# Altium schematic sheet MicroPET Base.SchDoc("MicroPET Base")
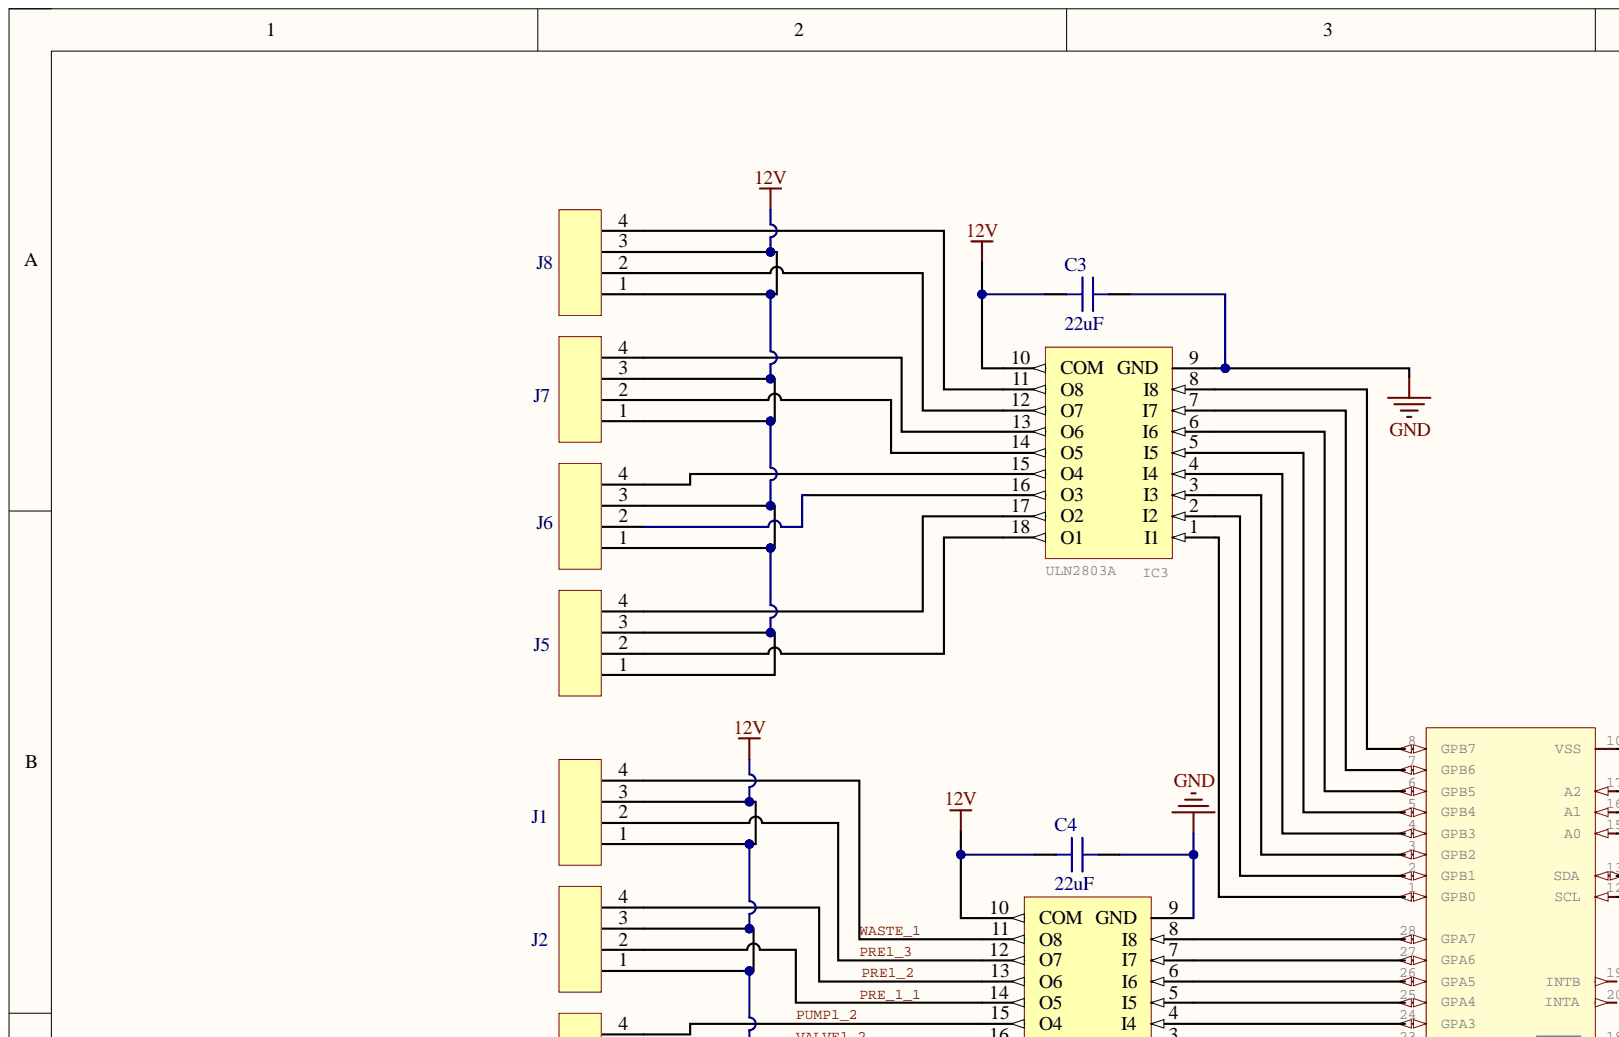
[diagram p0: MicroPET Base.SchDoc, top left]
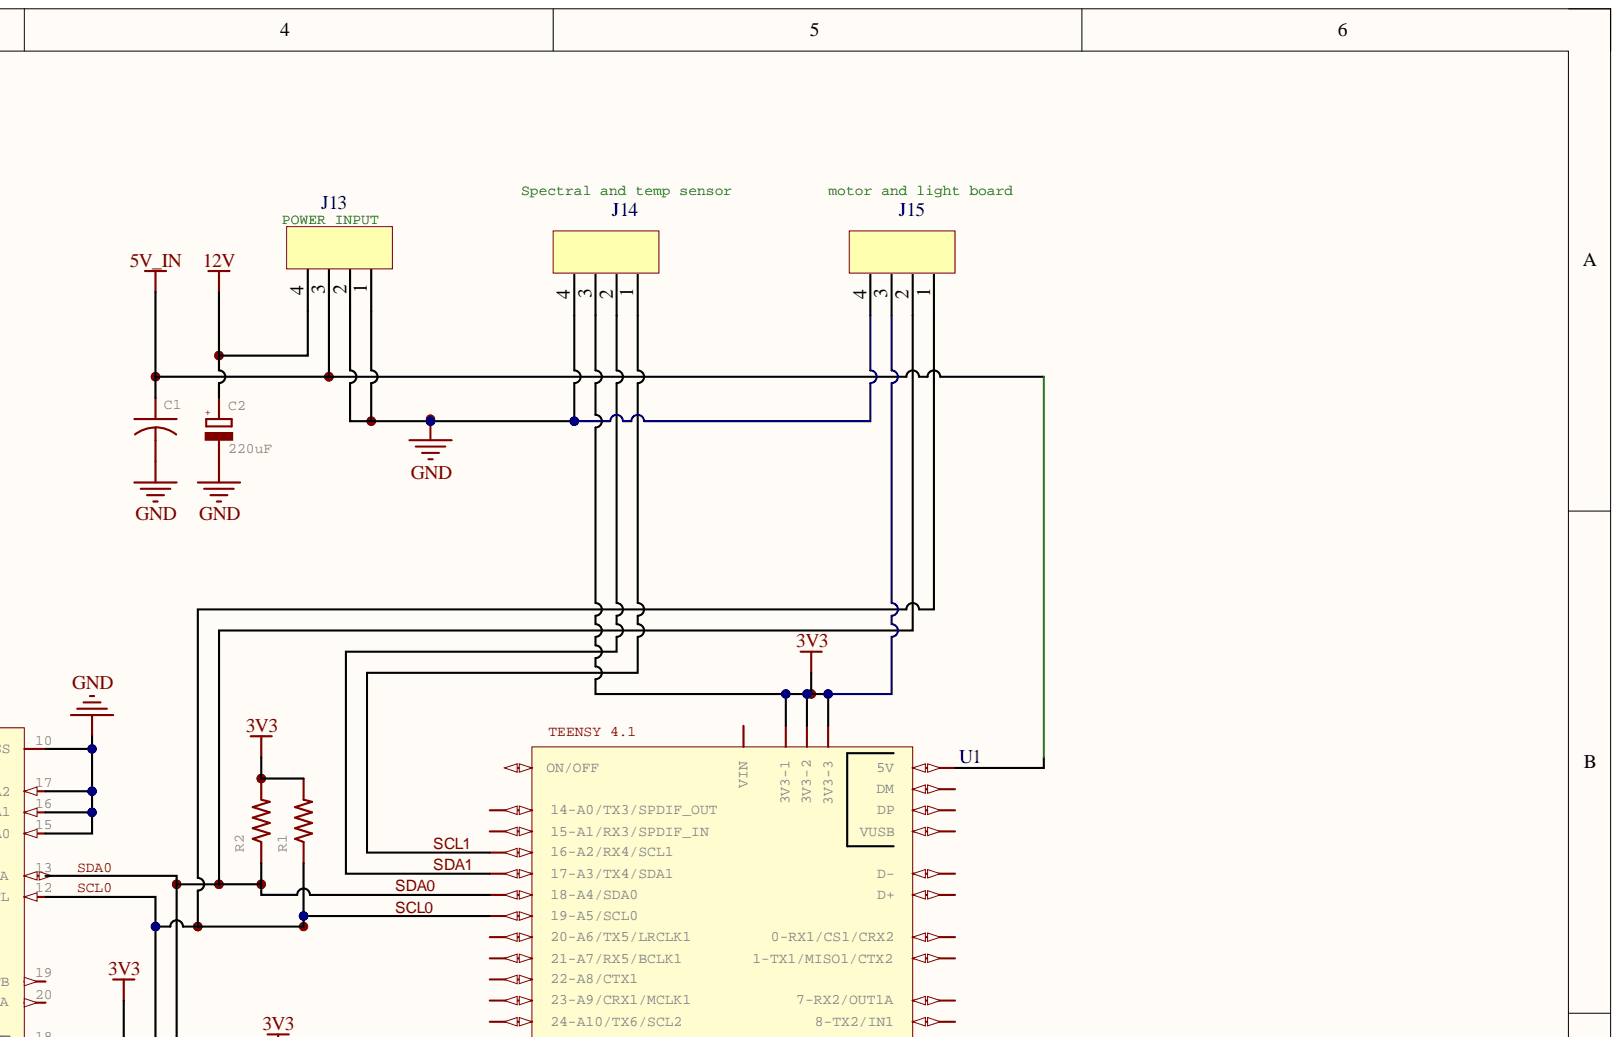
[diagram p1: MicroPET Base.SchDoc, top right]
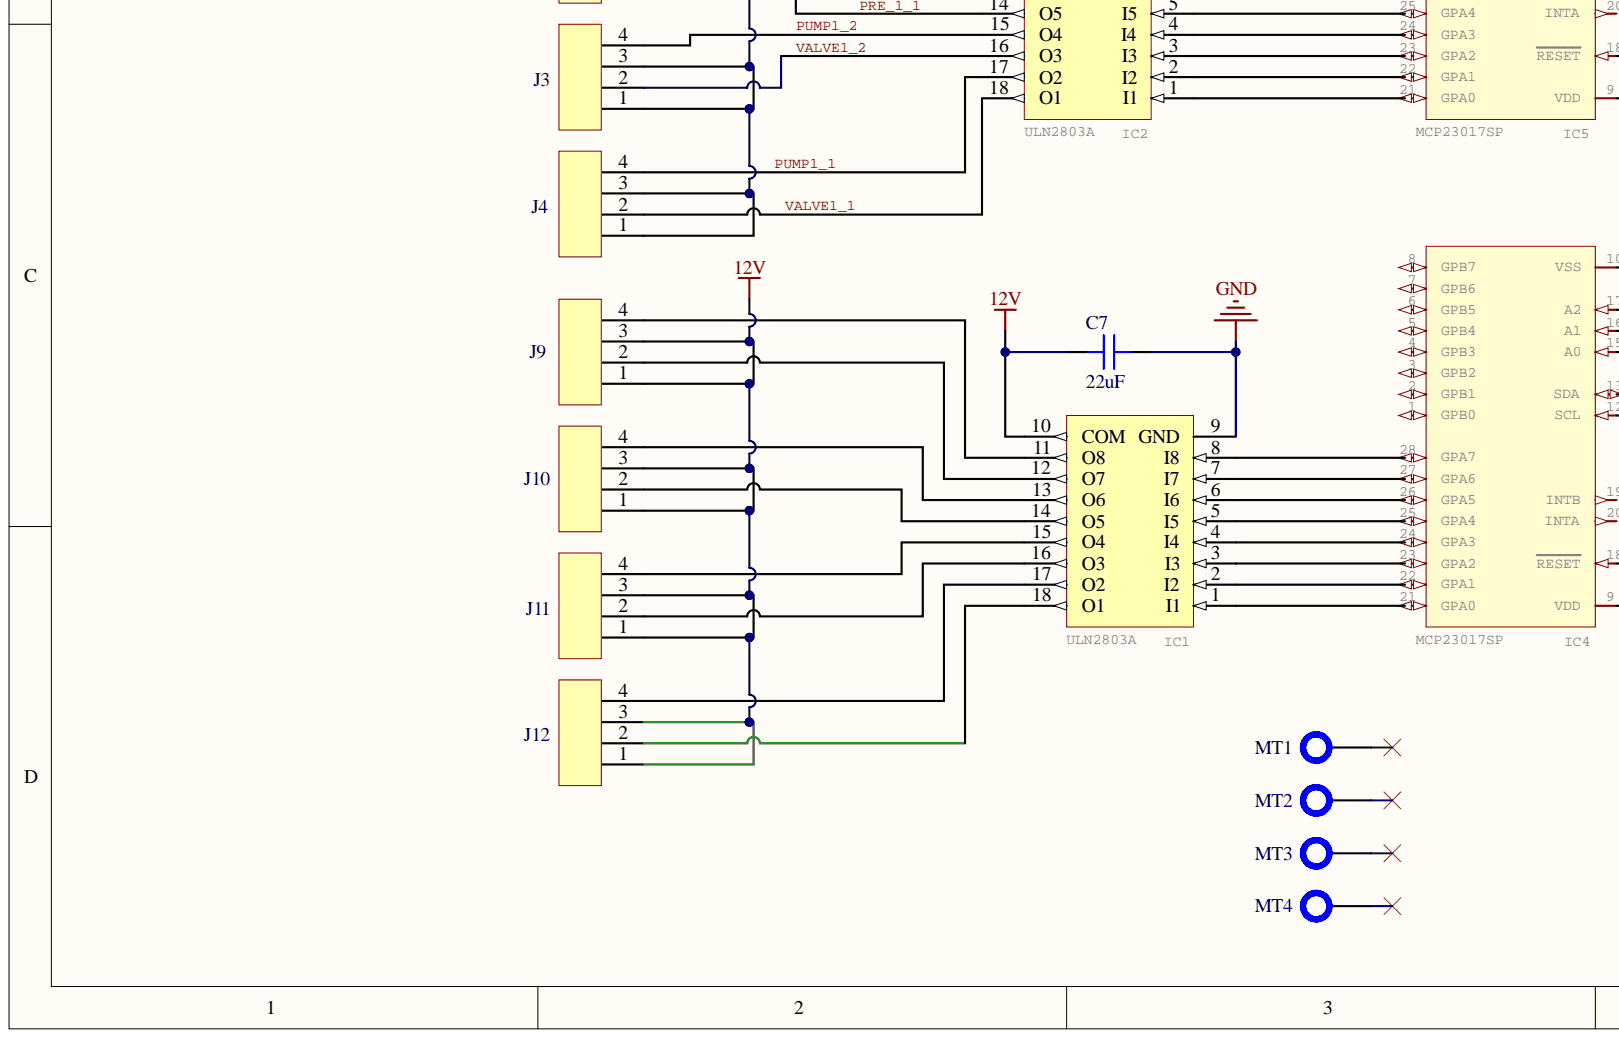
[diagram p2: MicroPET Base.SchDoc, bottom left]
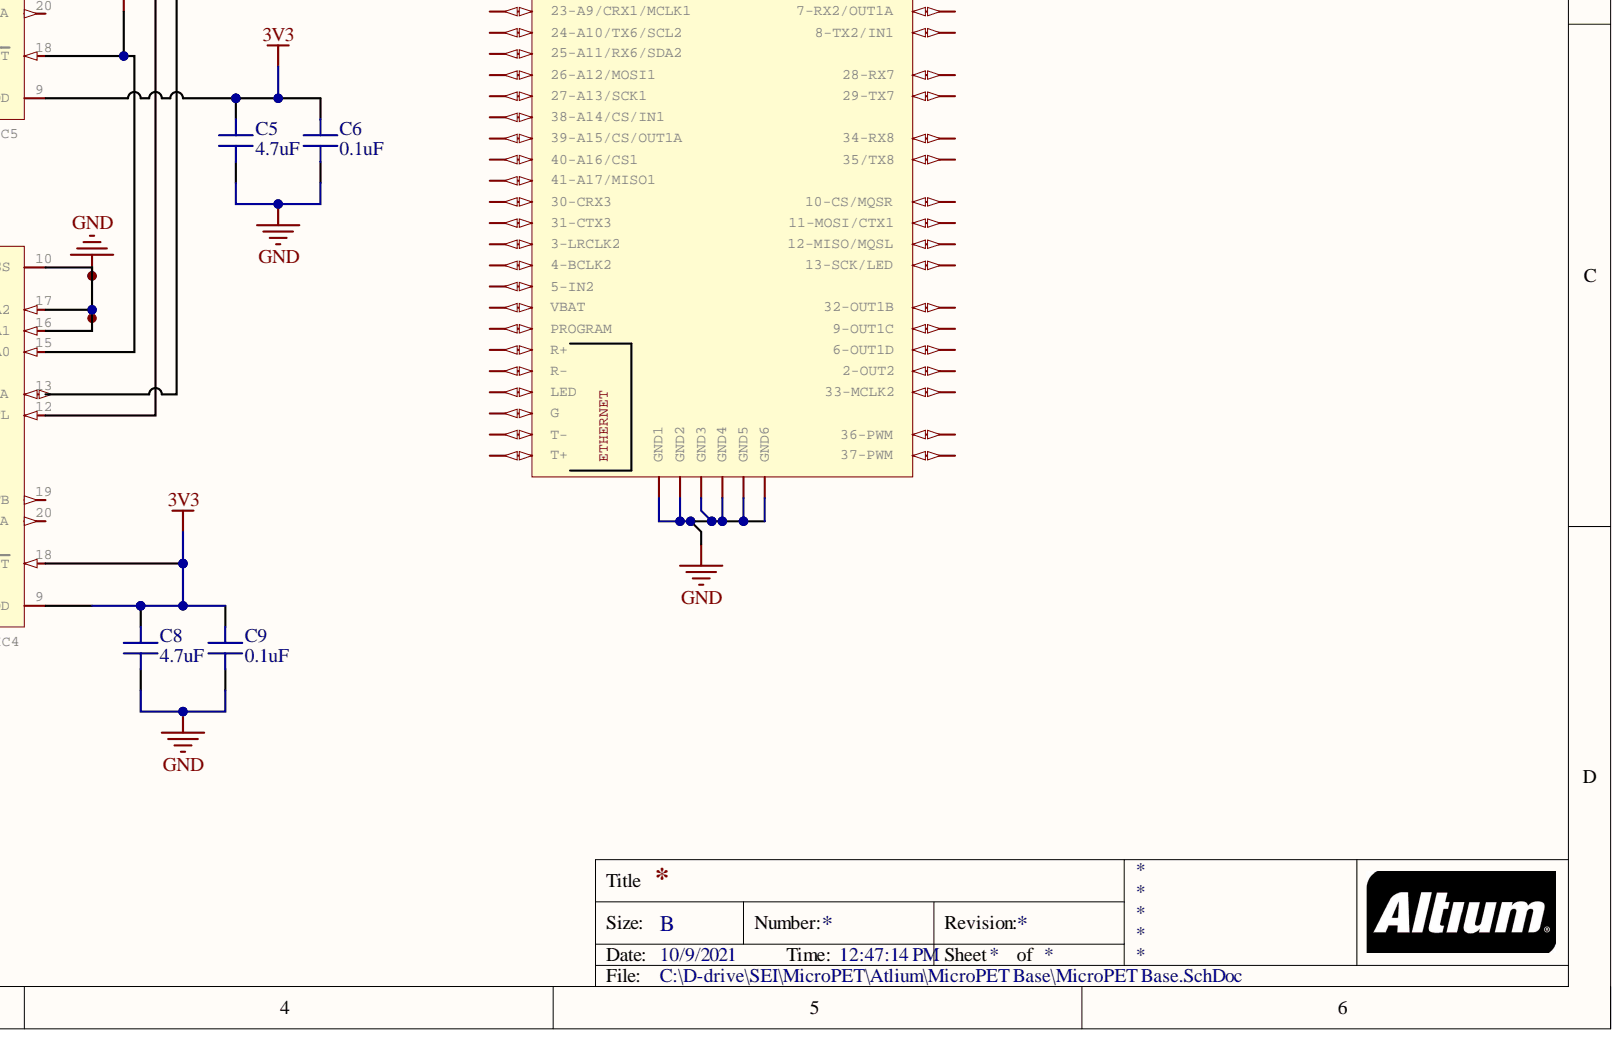
[diagram p3: MicroPET Base.SchDoc, bottom right]

## Parts
C1  C-USCHIP-1206(3216-METRIC)  [CAPC3216X135]  (value not drawn)
C2  220uF  [CAPPRD508W65D1000H1100B]
C3  22uF  [CAP_0805]
C4  22uF  [CAP_0805]
C5  4.7uF  [CAP_0603]
C6  0.1uF  [CAP_0402]
C7  22uF  [CAP_0805]
C8  4.7uF  [CAP_0603]
C9  0.1uF  [CAP_0402]
IC1  ULN2803A  [DIL18]
IC2  ULN2803A  [DIL18]
IC3  ULN2803A  [DIL18]
IC4  MCP23017SP  [DIL28-3]
IC5  MCP23017SP  [DIL28-3]
J1  B04B-ZESK-1D(T)(LF)(SN)(N)  [B04B-ZESK]  (value not drawn)
J2  B04B-ZESK-1D(T)(LF)(SN)(N)  [B04B-ZESK]  (value not drawn)
J3  B04B-ZESK-1D(T)(LF)(SN)(N)  [B04B-ZESK]  (value not drawn)
J4  B04B-ZESK-1D(T)(LF)(SN)(N)  [B04B-ZESK]  (value not drawn)
J5  B04B-ZESK-1D(T)(LF)(SN)(N)  [B04B-ZESK]  (value not drawn)
J6  B04B-ZESK-1D(T)(LF)(SN)(N)  [B04B-ZESK]  (value not drawn)
J7  B04B-ZESK-1D(T)(LF)(SN)(N)  [B04B-ZESK]  (value not drawn)
J8  B04B-ZESK-1D(T)(LF)(SN)(N)  [B04B-ZESK]  (value not drawn)
J9  B04B-ZESK-1D(T)(LF)(SN)(N)  [B04B-ZESK]  (value not drawn)
J10  B04B-ZESK-1D(T)(LF)(SN)(N)  [B04B-ZESK]  (value not drawn)
J11  B04B-ZESK-1D(T)(LF)(SN)(N)  [B04B-ZESK]  (value not drawn)
J12  B04B-ZESK-1D(T)(LF)(SN)(N)  [B04B-ZESK]  (value not drawn)
J13  B04B-ZESK-1D(T)(LF)(SN)(N)  [B04B-ZESK]  (value not drawn)
J14  B04B-ZESK-1D(T)(LF)(SN)(N)  [B04B-ZESK]  (value not drawn)
J15  B04B-ZESK-1D(T)(LF)(SN)(N)  [B04B-ZESK]  (value not drawn)
MT1  M3_MountHole  [M3_MountHole]  (value not drawn)  (no BOM)
MT2  M3_MountHole  [M3_MountHole]  (value not drawn)  (no BOM)
MT3  M3_MountHole  [M3_MountHole]  (value not drawn)  (no BOM)
MT4  M3_MountHole  [M3_MountHole]  (value not drawn)  (no BOM)
R1  R-US_R0603  [R0603]  (value not drawn)
R2  R-US_R0603  [R0603]  (value not drawn)
U1  TEENSY41  TEENSY4.1: TEENSY 4.1  [TEENSY41]

## Nets
3V3: C5.2 C6.2 C8.2 C9.2 IC4.9(VDD) IC4.15(A0) IC4.18(RESET) IC5.9(VDD) IC5.18(RESET) J14.3 J15.3 R1.2 R2.2 U1.3V3-1 U1.3V3-2 U1.3V3-3
5V_IN: C1.1 J13.3 U1.+5V(5V)
12V: C2.1 C3.1 C4.1 C7.1 IC1.10(COM) IC2.10(COM) IC3.10(COM) J1.1 J1.3 J2.1 J2.3 J3.1 J3.3 J4.1 J4.3 J5.1 J5.3 J6.1 J6.3 J7.1 J7.3 J8.1 J8.3 J9.1 J9.3 J10.1 J10.3 J11.1 J11.3 J12.1 J12.3 J13.4
GND: C1.2 C2.2 C3.2 C4.2 C5.1 C6.1 C7.2 C8.1 C9.1 IC1.9(GND) IC2.9(GND) IC3.9(GND) IC4.10(VSS) IC4.16(A1) IC4.17(A2) IC5.10(VSS) IC5.15(A0) IC5.16(A1) IC5.17(A2) J13.1 J13.2 J14.4 J15.4 U1.GND1 U1.GND2 U1.GND3 U1.GND4 U1.GND5 U1.GND6
PRE1_2: IC2.13(O6) J2.4
PRE1_3: IC2.12(O7) J1.2
PRE_1_1: IC2.14(O5) J2.2
PUMP1_1: IC2.17(O2) J4.4
PUMP1_2: IC2.15(O4) J3.4
SCL0: IC4.12(SCL) IC5.12(SCL) J15.1 R1.1 U1.19-A5/SCL0
SCL1: J14.1 U1.16-A2/RX4/SCL1
SDA0: IC4.13(SDA) IC5.13(SDA) J15.2 R2.1 U1.18-A4/SDA0
SDA1: J14.2 U1.17-A3/TX4/SDA1
VALVE1_1: IC2.18(O1) J4.2
VALVE1_2: IC2.16(O3) J3.2
WASTE_1: IC2.11(O8) J1.4
NetIC1_1: IC1.1(I1) IC4.21(GPA0)
NetIC1_2: IC1.2(I2) IC4.22(GPA1)
NetIC1_3: IC1.3(I3) IC4.23(GPA2)
NetIC1_4: IC1.4(I4) IC4.24(GPA3)
NetIC1_5: IC1.5(I5) IC4.25(GPA4)
NetIC1_6: IC1.6(I6) IC4.26(GPA5)
NetIC1_7: IC1.7(I7) IC4.27(GPA6)
NetIC1_8: IC1.8(I8) IC4.28(GPA7)
NetIC1_11: IC1.11(O8) J9.4
NetIC1_12: IC1.12(O7) J9.2
NetIC1_13: IC1.13(O6) J10.4
NetIC1_14: IC1.14(O5) J10.2
NetIC1_15: IC1.15(O4) J11.4
NetIC1_16: IC1.16(O3) J11.2
NetIC1_17: IC1.17(O2) J12.4
NetIC1_18: IC1.18(O1) J12.2
NetIC2_1: IC2.1(I1) IC5.21(GPA0)
NetIC2_2: IC2.2(I2) IC5.22(GPA1)
NetIC2_3: IC2.3(I3) IC5.23(GPA2)
NetIC2_4: IC2.4(I4) IC5.24(GPA3)
NetIC2_5: IC2.5(I5) IC5.25(GPA4)
NetIC2_6: IC2.6(I6) IC5.26(GPA5)
NetIC2_7: IC2.7(I7) IC5.27(GPA6)
NetIC2_8: IC2.8(I8) IC5.28(GPA7)
NetIC3_1: IC3.1(I1) IC5.1(GPB0)
NetIC3_2: IC3.2(I2) IC5.2(GPB1)
NetIC3_3: IC3.3(I3) IC5.3(GPB2)
NetIC3_4: IC3.4(I4) IC5.4(GPB3)
NetIC3_5: IC3.5(I5) IC5.5(GPB4)
NetIC3_6: IC3.6(I6) IC5.6(GPB5)
NetIC3_7: IC3.7(I7) IC5.7(GPB6)
NetIC3_8: IC3.8(I8) IC5.8(GPB7)
NetIC3_11: IC3.11(O8) J8.4
NetIC3_12: IC3.12(O7) J8.2
NetIC3_13: IC3.13(O6) J7.4
NetIC3_14: IC3.14(O5) J7.2
NetIC3_15: IC3.15(O4) J6.4
NetIC3_16: IC3.16(O3) J6.2
NetIC3_17: IC3.17(O2) J5.4
NetIC3_18: IC3.18(O1) J5.2

Not connected: IC4 1(GPB0) 2(GPB1) 3(GPB2) 4(GPB3) 5(GPB4) 6(GPB5) 7(GPB6) 8(GPB7) 19(INTB) 20(INTA); IC5 19(INTB) 20(INTA); MT1 M1; MT2 M1; MT3 M1; MT4 M1; U1 0-RX1/CS1/CRX2 1-TX1/MISO1/CTX2 2-OUT2 3-LRCLK2 4-BCLK2 5-IN2 6-OUT1D 7-RX2/OUT1A 8-TX2/IN1 9-OUT1C 10-CS\MQSR 11-MOSI/CTX1 12-MISO/MQSL 13-SCK/LED 14-A0/TX3/SPDIF_OUT 15-A1/RX3/SPDIF_IN 20-A6/TX5/LRCLK1 21-A7/RX5/BCLK1 22-A8/CTX1 23-A9/CRX1/MCLK1 24-A10/TX6/SCL2 25-A11/RX6/SDA2 26-A12/MOSI1 27-A13/SCK1 28-RX7 29-TX7 30-CRX3 31-CTX3 32-OUT1B 33-MCLK2 34-RX8 35-TX8(35/TX8) 36-PWM 37-PWM 38-A14/CS/IN1 39-A15/CS/OUT1A 40-A16/CS1 41-A17/MISO1 D+ D- DM DP G LED ON/OFF PROGRAM R+ R- T+ T- VBAT VIN VUSB

## Library data of the parts
C1 = C-USCHIP-1206(3216-METRIC): Capacitor - ANSI; DeviceSetName: C-US; GateName_1: G$1; CATEGORY: Capacitor; Component Kind: Standard; DeviceName: CHIP-1206(3216-METRIC); Library Name: Capacitor.IntLib; LibraryName: Capacitor; MANUFACTURER: MANUFACTURER; MPN: MPN; OPERATING_TEMP: OPERATING_TEMP; PART_STATUS: PART_STATUS; ROHS_COMPLIANT: ROHS_COMPLIANT; SERIES: SERIES; SUB-CATEGORY: SUB-CATEGORY; SymbolName_1: C-US; THERMALLOSS: THERMALLOSS; TYPE: TYPE; VOLTAGE_RATING: VOLTAGE_RATING
C2 = C-POLRADIAL-11MM-DIA: Capacitor Polarised - Generic; DeviceSetName: C-POL; GateName_1: G$1; SymbolName_1: CPOL; +: +; CATEGORY: Capacitor; Component Kind: Standard; DeviceName: RADIAL-11MM-DIA; Library Name: Capacitor.IntLib; LibraryName: Capacitor; MANUFACTURER: MANUFACTURER; MPN: MPN; OPERATING_TEMP: OPERATING_TEMP; PART_STATUS: PART_STATUS; ROHS_COMPLIANT: ROHS_COMPLIANT; SERIES: SERIES; SUB-CATEGORY: SUB-CATEGORY; THERMALLOSS: THERMALLOSS; TYPE: TYPE; VOLTAGE_RATING: VOLTAGE_RATING
C3 C4 C7 = GRM21BR61E226ME44L: CAP CER 22UF 25V X5R 0805; Manufacturer 1: Murata; Database Table Name: CeramicCapacitors; Material: Ceramic; Tolerance: 20%; Capacitance: 22uF; Case Code (Imperial): 0805; Case Code (Metric): 2012; Case/Package: 0805; Component Kind: Standard; ComponentLink1Description: https://datasheet.ciiva.com/22787/1747471-227; ComponentLink1URL: https://datasheet.ciiva.com/22787/1747471-227; ComponentLink2Description: https://datasheet.ciiva.com/7719/1747471-7719; ComponentLink2URL: https://datasheet.ciiva.com/7719/1747471-7719; ComponentLink3Description: https://datasheet.ciiva.com/28584/1747471-285; ComponentLink3URL: https://datasheet.ciiva.com/28584/1747471-285; Composition: Ceramic; Depth: 1.25mm; Dielectric: X5R; Height: 1.25mm; Lead Free: Lead Free; Length: 2mm; Library Name: AltiumDBLib.DbLib; Manufacturer Part Number 1: GRM21BR61E226ME44L; Max Operating Temperature: 85°C; Min Operating Temperature: -55°C; Mount: Surface Mount; Package Quantity: 3000; Packaging: Tape and Reel; Part Name: GRM21BR61E226ME44L; Pricing 1: 1=0.49000, 10=0.34800, 100=0.22910, 500=0.169; REACH SVHC: No SVHC; RoHS: Yes; Stock 1: 312754; Supplier 1: Digi-Key; Supplier Part Number 1: 490-10749-1-ND; Termination: SMD/SMT; Thickness: 0.057inch; Voltage: 25V; Voltage Rating: 25V; Voltage Rating (DC): 25V; Weight: 0.000335oz; Width: 1.25mm
C5 C8 = C1608X5R1C475K080AC: CAP CER 4.7UF 16V X5R 0603; Manufacturer 1: TDK; Database Table Name: CeramicCapacitors; Dielectric Material: Ceramic; Tolerance: 10%; Capacitance: 4.7uF; Case Code (Imperial): 0603; Case Code (Metric): 1608; Case/Package: 0603; Component Kind: Standard; ComponentLink1Description: https://datasheet.ciiva.com/6251/tdkmlcccapra; ComponentLink1URL: https://datasheet.ciiva.com/6251/tdkmlcccapra; ComponentLink2Description: https://datasheet.ciiva.com/22694/1796654-226; ComponentLink2URL: https://datasheet.ciiva.com/22694/1796654-226; ComponentLink3Description: https://datasheet.ciiva.com/28577/mlcc-commer; ComponentLink3URL: https://datasheet.ciiva.com/28577/mlcc-commer; ComponentLink4Description: https://datasheet.ciiva.com/6251/tdkmlcccapra; ComponentLink4URL: https://datasheet.ciiva.com/6251/tdkmlcccapra; ComponentLink5Description: https://datasheet.ciiva.com/22725/1913358-227; ComponentLink5URL: https://datasheet.ciiva.com/22725/1913358-227; ComponentLink6Description: https://datasheet.ciiva.com/23802/1796654-238; ComponentLink6URL: https://datasheet.ciiva.com/23802/1796654-238; Depth: 0.8mm; Dielectric: X5R; Height: 0.8mm; Lead Free: Lead Free; Length: 1.6mm; Library Name: AltiumDBLib.DbLib; Manufacturer Part Number 1: C1608X5R1C475K080AC; Max Operating Temperature: 85°C; Min Operating Temperature: -55°C; Mount: Surface Mount; Package Quantity: 1; Packaging: Tape and Reel; Part Name: C1608X5R1C475K080AC; Pricing 1: 1=0.31000, 10=0.21400, 100=0.12130, 500=0.085; REACH SVHC: No SVHC; RoHS: Yes; Stock 1: 1103730; Supplier 1: Digi-Key; Supplier Part Number 1: 445-7478-1-ND; Termination: SMD/SMT; Thickness: 0.035inch; Voltage: 16V; Voltage Rating: 16V; Voltage Rating (DC): 16V; Weight: 7.1E-05oz; Width: 0.031inch
C6 C9 = GRM155R71H104ME14D: 0402 0.1 uF 50 V ±20% Tolerance X7R SMT Monol; Manufacturer 1: Murata; Database Table Name: CeramicCapacitors; Voltage: 50V; Capacitance: 0.1uF; Case/Package: 0402; Component Kind: Standard; ComponentLink1Description: http://www.mouser.com/ds/2/281/c02e-2905.pdf?; ComponentLink1URL: http://www.mouser.com/ds/2/281/c02e-2905.pdf?; ComponentLink2Description: http://components.arrow.com/part/dataview/032; ComponentLink2URL: http://components.arrow.com/part/dataview/032; ComponentLink3Description: http://search.murata.co.jp/Ceramy/image/img/A; ComponentLink3URL: http://search.murata.co.jp/Ceramy/image/img/A; ComponentLink4Description: http://psearch.en.murata.com/capacitor/produc; ComponentLink4URL: http://psearch.en.murata.com/capacitor/produc; Length: 0.039inch; Library Name: AltiumDBLib.DbLib; Manufacturer Part Number 1: GRM155R71H104ME14D; Max Operating Temperature: 125°C; Min Operating Temperature: -55°C; Mount: Surface Mount; Packaging: Cut Tape; Part Name: GRM155R71H104ME14D; Pricing 1: 1=0.10000, 10=0.03800, 100=0.01680, 500=0.011; RoHS: Yes; Stock 1: 360537; Supplier 1: Digi-Key; Supplier Part Number 1: 490-10701-1-ND; Thickness: 0.022inch; Tolerance: 20%; Voltage Rating: 50V; Width: 0.02inch
IC1-IC3 = ULN2803A_DIP: DRIVER ARRAY; GateName_1: G$1; POPULARITY: 5; SymbolName_1: 2801A; Component Kind: Standard; DeviceSetName: ULN2803A; Library Name: MicroPET Base.SCHLIB; LibraryName: uln-udn
IC4 IC5 = MCP23017SP: http://ww1.microchip.com/downloads/en/DeviceDoc/21952a.pdf   Source: http://ww1.microchip.com/downloads/en/DeviceDoc/21952a.pdf; MPN: MCP23017-E/SP; DeviceName: SP; GateName_1: G$1; POPULARITY: 2; Component Kind: Standard; DeviceSetName: MCP23017; LibraryName: microchip; MF: MICROCHIP; OC_FARNELL: 1332088; OC_NEWARK: 31K2959; SymbolName_1: MCP23017; Library Name: MicroPET Base.SCHLIB
J1-J15 = B04B-ZESK-1D(T)(LF)(SN)(N): CONN HEADER VERT 4POS 1.5MM; Manufacturer: JST; Connector Type: Male Pin; Database Table Name: Connectors; Gender: Male; MF: JST Sales; MP: S4B-PH-K-S_LF__SN_; Number of Contacts: 4; Pitch: 0.059inch; Category: Connectors; Color: Natural; Component Kind: Standard; ComponentLink1Description: https://datasheet.ciiva.com/742/eze-742229.pd; ComponentLink1URL: https://datasheet.ciiva.com/742/eze-742229.pd; ComponentLink2Description: https://datasheet.ciiva.com/46409/1706589-464; ComponentLink2URL: https://datasheet.ciiva.com/46409/1706589-464; Contact Material: Copper; Contact Plating: Tin; Contact Type: Male Pin; Digi-Key_Part_Number: 455-1721-ND; Digi-Key_Purchase_URL: https://www.digikey.com/product-detail/en/jst-sales-america-inc/S4B-PH-K-S_LF__SN_/455-1721-ND/926628?utm_source=snapeda&utm_medium=aggregator&utm_campaign=symbol; Library Name: AltiumDBLib.DbLib; Manufacturer Part Number: B04B-ZESK-1D(T)(LF)(SN)(N); Mount: Through Hole; Number of Positions: 4; Number of Rows: 1; Package: None; Package Quantity: 1664; Packaging: Tray; Part Name: B04B-ZESK-1D(T)(LF)(SN)(N); Pricing 1: 1=0.56000, 10=0.52800, 80=0.40450, 440=0.3518; REACH SVHC: Unknown; RoHS: Yes; Series: ZE; SnapEDA_Link: https://www.snapeda.com/parts/S4B-PH-K-S%28LF%29%28SN%29/JST%20Sales%20America%20Inc./view-part/?ref=snap; Stock 1: 793; Supplier 1: Digi-Key; Supplier Part Number 1: 455-2665-ND; Termination: Solder
MT1-MT4 = M3_MountHole: M3 Mounting Hole; Database Table Name: Misc.; Library Name: AltiumDBLib.DbLib; Part Name: M3_MountHole
R1 R2 = R-US_R0603: RESISTOR, American symbol; DeviceSetName: R-US_; GateName_1: G$1; POPULARITY: 77; SymbolName_1: R-US; Component Kind: Standard; DeviceName: R0603; Library Name: rcl.IntLib; LibraryName: rcl; SPICEPREFIX: R
U1 = TEENSY41: GateName_1: G$1; Component Kind: Standard; DeviceSetName: TEENSY41; ETHERNET: ETHERNET; LibraryName: teensy; SymbolName_1: TEENSY41; Library Name: MicroPET Base.SCHLIB
